# Altium schematic sheet RJ45.SchDoc
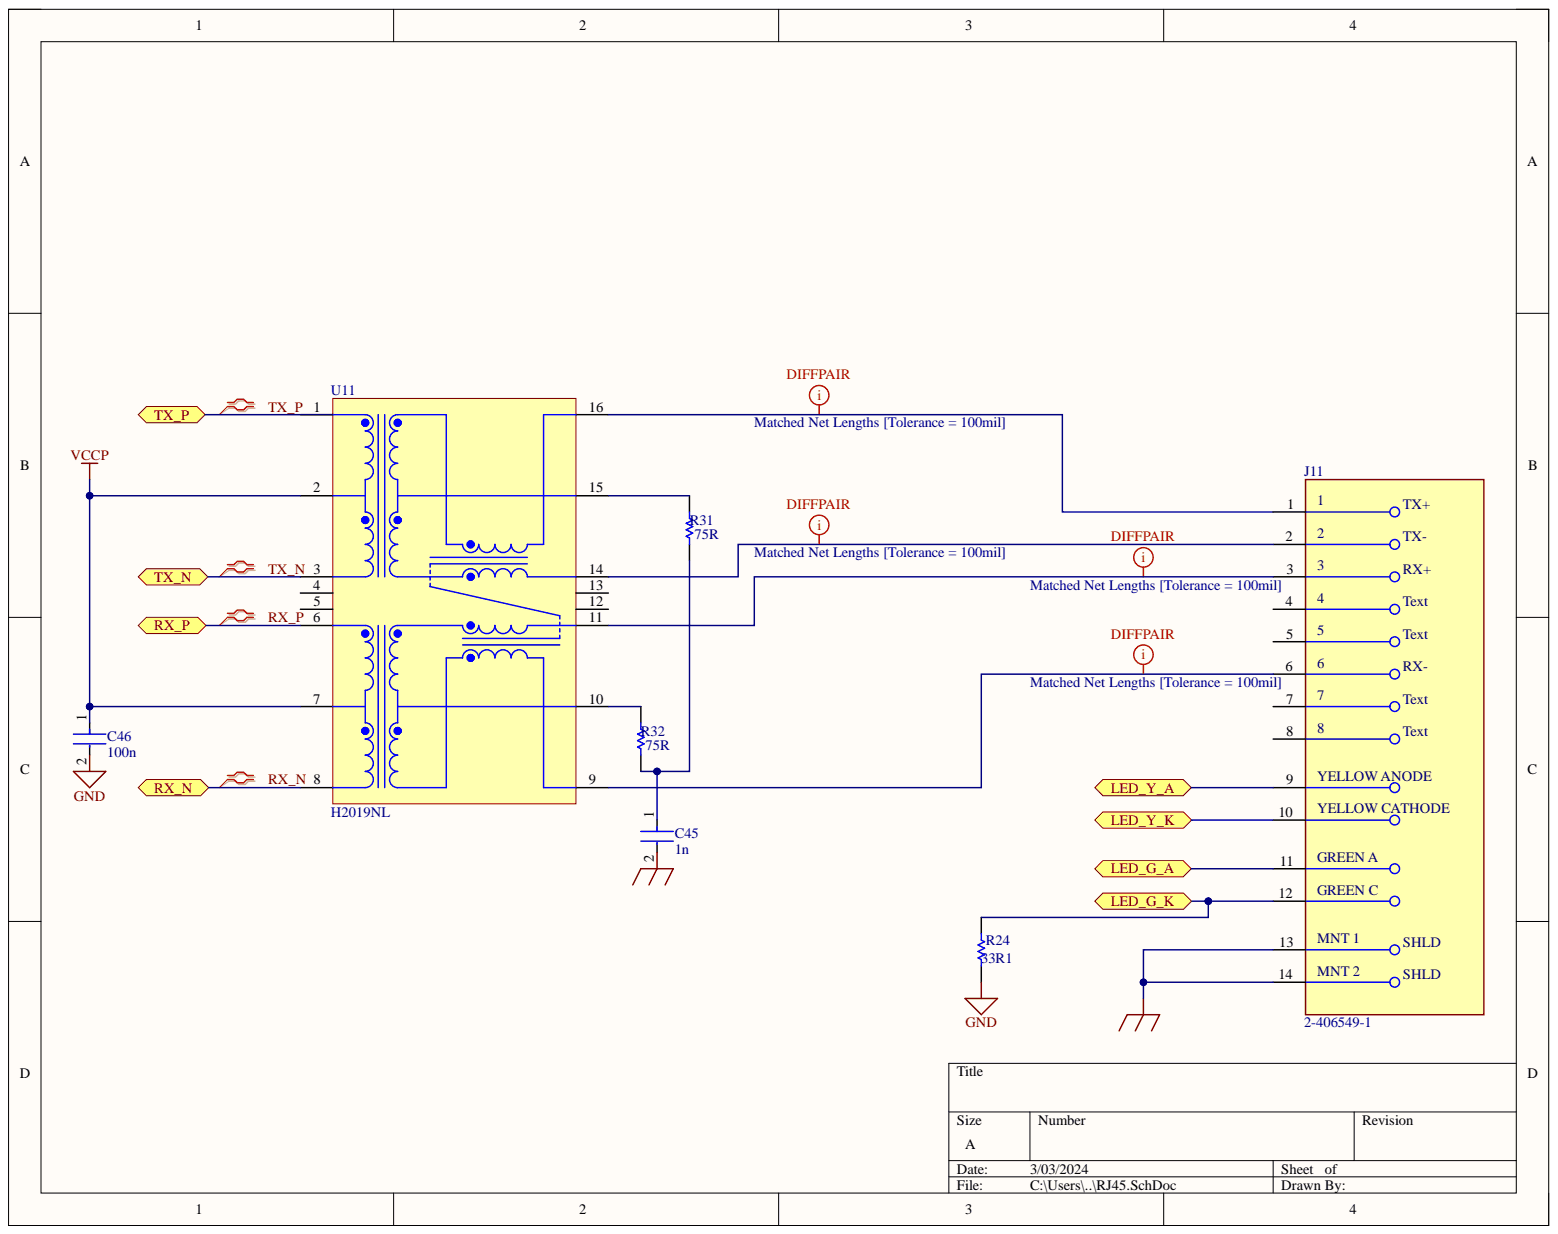
[diagram p0: RJ45.SchDoc]

## Parts
C45  1n  [FP-GRM033-0_03-MFG]
C46  100n  [FP-GRM033-0_03-MFG]
J11  2-406549-1  [FP-2-406549-1-MFG]
R24  33R1  [RESC1608X55X30LL20T20]
R31  75R  [RESC1608X55X30LL20T20]
R32  75R  [RESC1608X55X30LL20T20]
U11  H2019NL  [PULS-H2019FNL_V]

## Ports
LED_G_A, LED_G_K, LED_Y_A, LED_Y_K, RX_N, RX_P, TX_N, TX_P

## Nets
GND: C45.2 C46.2 J11.13 J11.14 R24.1
VCCP: C46.1 U11.2 U11.7
LED_G_A: J11.11 ; port LED_G_A
LED_Y_A: J11.9 ; port LED_Y_A
LED_Y_K: J11.10 ; port LED_Y_K
RX_N: U11.8 ; port RX_N
RX_P: U11.6 ; port RX_P
TX_N: U11.3 ; port TX_N
TX_P: U11.1 ; port TX_P
NetC45_1: C45.1 R31.1 R32.1
NetJ11_1: J11.1 U11.16
NetJ11_2: J11.2 U11.14
NetJ11_3: J11.3 U11.11
NetJ11_6: J11.6 U11.9
NetJ11_12: J11.12 R24.2
NetR31_2: R31.2 U11.15
NetR32_2: R32.2 U11.10
N00001: ; port LED_G_K

Not connected: J11 4 5 7 8; U11 4 5 12 13

## Library data of the parts
C45 C46 = CMP-06035-000062-2: Chip Multilayer Ceramic Capacitors for General Purpose, 0201, 0.10uF, X5R, 15%, 10%, 6.3V; Capacitance: 100nF; Case Code (Imperial): 0201; Case Code (Metric): 0603; Case/Package: 0201; Component Kind: Standard; Depth: 0.3mm; Dielectric: X5R; Height: 0.3mm; Lead Free: Lead Free; Length: 0.6mm; Library Name: Altium Content Vault; Material: Ceramic; Max Operating Temperature: 85°C; Min Operating Temperature: -55°C; Mount: Surface Mount; Package Quantity: 1; Packaging: Tape and Reel; Radiation Hardening: No; REACH SVHC: No SVHC; Termination: SMD/SMT; Thickness: 0.013inch; Tolerance: 10%; Voltage: 6.3V; Voltage Rating: 6.3V; Voltage Rating (DC): 6.3V; Weight: 6E-06oz; Width: 0.012inch
J11 = CMP-2000-05541-2: CONN MOD JACK 8P8C R/A SHIELDED; Approvals: UL; Current Rating: 1.5A; Direction: Up; Element Configuration: Single; Gender: Female; Keyed: No; Max Voltage Rating (AC): 150V; Number of Contacts: 8; Number of Ports: 1; PCB Mounting Orientation: Right Angle; PCB Thickness: 1.57mm; Pitch: 2.03mm; Tail Length: 2.54mm; Color: Yellow; Component Kind: Standard; Connector Type: Jack; Contact Material: Bronze; Contact Plating: Gold; Depth: 15.75mm; Flammability Rating: UL94 V-0; Housing Color: Black; Housing Material: Thermoplastic; Length: 17.86mm; Library Name: Altium Content Vault; Max Operating Temperature: 85°C; Min Operating Temperature: -40°C; Mount: Panel; Number of Positions: 8; Number of Positions Loaded: 8; Number of Rows: 1; Orientation: Right Angle; Package Quantity: 63; Packaging: Tray; Radiation Hardening: No; RoHS Compliant: Yes; Series: -; Shielding: Shielded; Termination: Solder; Voltage Rating: 150V; Weight: 0.144729oz; Width: 17.27mm
R24 R31 R32 = CMP-2000-00025-1: Manufacturer: Panasonic; Part Number: ERA3AEB301V; Component Kind: Standard; Library Name: Altium Content Vault
U11 = CMP-2000-06384-1: Telecom Transformer, 100BASE-TX, 0 to 70 degC, 16-Pin SMD, RoHS; Manufacturer: Pulse Electronics; Other Names: 553-2199; Other Related Documents: PackagesFNLvs.NL; Category: Transformers; Component Kind: Standard; Datasheet URL: http://productfinder.pulseeng.com/products/datasheets/H2019FNL.pdf; Datasheet Version: Rev. A, 06/2014; Family: PulseTransformers; Library Name: Altium Content Vault; Manufacturer URL: http://www.pulseelectronics.com/; Mounting Technology: SurfaceMount; Package Description: 16-Pin Surface Mount Device, Body 12.7 x 9.09 mm, Pitch 1.27 mm; Package Reference: H2019FNL; Standard Package: 40
